# Altium schematic sheet 48V-5V Buck.SchDoc
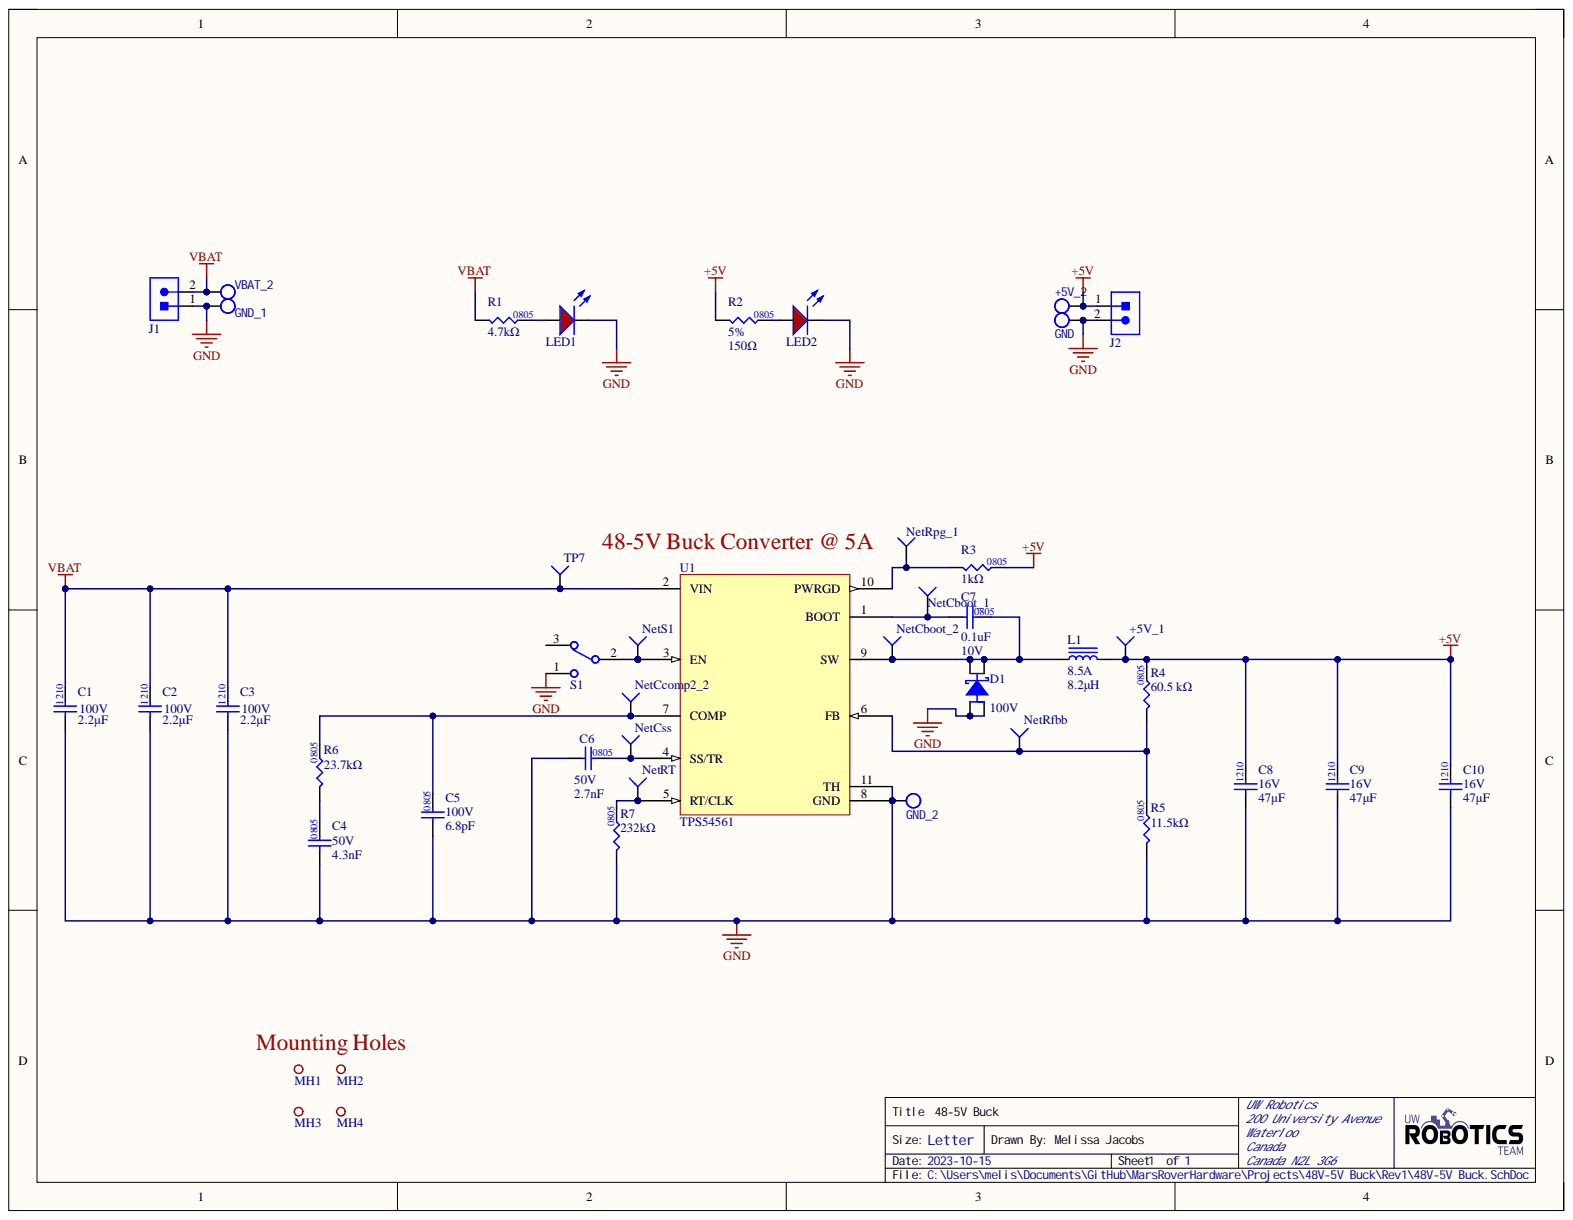
[diagram p0: 48V-5V Buck.SchDoc]

## Parts
+5V_1  TESTPOINT_1MM_CIRCLE  [TESTPOINT_CIRCLE_1MM]  (value not drawn)  (no BOM)
+5V_2  TESTPOINT_LOOP  [TESTPOINT_LOOP]  (value not drawn)
C1  value 2.2μF  Package/Footprint: 1210  Voltage Rating: 100V  [CAPC3225X270N]  (hidden comment: CAP_2.2uF_100V_1210_1)
C2  value 2.2μF  Package/Footprint: 1210  Voltage Rating: 100V  [CAPC3225X270N]  (hidden comment: CAP_2.2uF_100V_1210_1)
C3  value 2.2μF  Package/Footprint: 1210  Voltage Rating: 100V  [CAPC3225X270N]  (hidden comment: CAP_2.2uF_100V_1210_1)
C4  value 4.3nF  Package: 0805  Voltage Rating: 50V  [CAP2012]  (hidden comment: CAP_4.3nF_50V_0805)
C5  value 6.8pF  Package: 0805  Voltage Rating: 100V  [CAP2012]  (hidden comment: CAP_6.8pF_100V_0805_0.1pF)
C6  value 2.7nF  Package/Footprint: 0805  Voltage Rating: 50V  [CAP0805]  (hidden comment: CAP_2.7nF_50V_0805)
C7  value 0.1uF  Package/Footprint: 0805  [CAP0805]  (hidden comment: CAP_0.1uF_10V_0805)
C8  value 47μF  Package/Footprint: 1210  Voltage Rating: 16V  [CAPC3225X270N]  (hidden comment: CAP_47uF_16V_1210)
C9  value 47μF  Package/Footprint: 1210  Voltage Rating: 16V  [CAPC3225X270N]  (hidden comment: CAP_47uF_16V_1210)
C10  value 47μF  Package/Footprint: 1210  Voltage Rating: 16V  [CAPC3225X270N]  (hidden comment: CAP_47uF_16V_1210)
D1  Vr (Max): 100V  [D-PAK]  (hidden comment: DIO_SCHOTTKY_100V_5.5A)
GND  TESTPOINT_LOOP  [TESTPOINT_LOOP]  (value not drawn)
GND_1  TESTPOINT_LOOP  [TESTPOINT_LOOP]  (value not drawn)
GND_2  TESTPOINT_LOOP  [TESTPOINT_LOOP]  (value not drawn)
J1  CON_2POS_SCREW_TERM  [1935161]  (value not drawn)
J2  CON_2POS_SCREW_TERM  [1935161]  (value not drawn)
L1  value 8.2μH  Current Rating: 8.5A  [IND_8.2uH_8.5A]  (hidden comment: IND_8.2uH_8.5A)
LED1  LED_RED  [LED_0805_RED]  (value not drawn)
LED2  Package/Footprint: 0805  [LED_0805_RED]  (hidden comment: LED_RED)
MH1  MOUNTING_HOLE_M3_GIMBAL_REV2  [MOUNTING_HOLE_3mm_GIMBAL_REV2]  (value not drawn)  (no BOM)
MH2  MOUNTING_HOLE_M3_GIMBAL_REV2  [MOUNTING_HOLE_3mm_GIMBAL_REV2]  (value not drawn)  (no BOM)
MH3  MOUNTING_HOLE_M3_GIMBAL_REV2  [MOUNTING_HOLE_3mm_GIMBAL_REV2]  (value not drawn)  (no BOM)
MH4  MOUNTING_HOLE_M3_GIMBAL_REV2  [MOUNTING_HOLE_3mm_GIMBAL_REV2]  (value not drawn)  (no BOM)
NetCboot_1  TESTPOINT_1MM_CIRCLE  [TESTPOINT_CIRCLE_1MM]  (value not drawn)  (no BOM)
NetCboot_2  TESTPOINT_1MM_CIRCLE  [TESTPOINT_CIRCLE_1MM]  (value not drawn)  (no BOM)
NetCcomp2_2  TESTPOINT_1MM_CIRCLE  [TESTPOINT_CIRCLE_1MM]  (value not drawn)  (no BOM)
NetCss  TESTPOINT_1MM_CIRCLE  [TESTPOINT_CIRCLE_1MM]  (value not drawn)  (no BOM)
NetRfbb  TESTPOINT_1MM_CIRCLE  [TESTPOINT_CIRCLE_1MM]  (value not drawn)  (no BOM)
NetRpg_1  TESTPOINT_1MM_CIRCLE  [TESTPOINT_CIRCLE_1MM]  (value not drawn)  (no BOM)
NetRT  TESTPOINT_1MM_CIRCLE  [TESTPOINT_CIRCLE_1MM]  (value not drawn)  (no BOM)
NetS1  TESTPOINT_1MM_CIRCLE  [TESTPOINT_CIRCLE_1MM]  (value not drawn)  (no BOM)
R1  value 4.7kΩ  Package: 0805  [RES0805]  (hidden comment: RES_4.7kΩ_0805_5%)
R2  value 150Ω  Tolerance: 5%  [RES0805]  (hidden comment: RES_150Ω_0805_5%)
R3  value 1kΩ  Package: 0805  [RES0805]  (hidden comment: RES_1kΩ_0805_1%)
R4  value 60.5 kΩ  Package/Footprint: 0805  [RES0805]  (hidden comment: RES_60.4kΩ_0805_1%)
R5  value 11.5kΩ  Package/Footprint: 0805  [RES0805]  (hidden comment: RES_11.5kΩ_0805_0.1%)
R6  value 23.7kΩ  Package: 0805  [RES0805]  (hidden comment: RES_23.7kΩ_0805_1%)
R7  value 232kΩ  Package: 0805  [RES0805]  (hidden comment: RES_232kΩ_0805_1%)
S1  SW_SLIDE_TOGGLE  [SW_SLIDE_TOGGLE]  (value not drawn)
TP7  TESTPOINT_1MM_CIRCLE  [TESTPOINT_CIRCLE_1MM]  (value not drawn)  (no BOM)
U1  TPS54561  [BUCK_TPS54561DPRR]
VBAT_2  TESTPOINT_LOOP  [TESTPOINT_LOOP]  (value not drawn)

## Nets
+5V: +5V_1.1 +5V_2.1 C8.2 C9.2 C10.2 J2.1 L1.2 R2.1 R3.2 R4.2
GND: C1.1 C2.1 C3.1 C4.1 C5.1 C6.1 C8.1 C9.1 C10.1 D1.1 D1.3 GND.1 GND_1.1 GND_2.1 J1.1 J2.2 LED1.2 LED2.2 R5.1 R7.1 S1.1 U1.8(GND) U1.11(TH)
VBAT: C1.2 C2.2 C3.2 J1.2 R1.1 TP7.1 U1.2(VIN) VBAT_2.1
NetC4_2: C4.2 R6.1
NetC5_2: C5.2 NetCcomp2_2.1 R6.2 U1.7(COMP)
NetC6_2: C6.2 NetCss.1 U1.4(SS/TR)
NetC7_1: C7.1 NetCboot_1.1 U1.1(BOOT)
NetC7_2: C7.2 D1.2 D1.4 L1.1 NetCboot_2.1 U1.9(SW)
NetLED1_1: LED1.1 R1.2
NetLED2_1: LED2.1 R2.2
NetNetRfbb_1: NetRfbb.1 R4.1 R5.2 U1.6(FB)
NetNetRpg_1_1: NetRpg_1.1 R3.1 U1.10(PWRGD)
NetNetRT_1: NetRT.1 R7.2 U1.5(RT/CLK)
NetNetS1_1: NetS1.1 S1.2 U1.3(EN)

Not connected: S1 3

## Library data of the parts
+5V_1 NetCboot_1 NetCboot_2 NetCcomp2_2 NetCss NetRfbb NetRpg_1 NetRT NetS1 TP7 = TESTPOINT_1MM_CIRCLE: TESTPOINT 1MM CIRCLE; Library Name: UWRT_Schematic_Library_2020.SchLib
+5V_2 GND GND_1 GND_2 VBAT_2 = TESTPOINT_LOOP: TESTPOINT THRUHOLE; Manufacturer 1: Keystone Electronics; Manufacturer Part Number 1: 5002; Package/Footprint: TH; Component Kind: Standard; Mounting Type: TH; Supplier 1: Digi-Key; Supplier Part Number 1: 36-5002-ND; Library Name: UWRT_Schematic_Library_2020.SchLib
C1-C3 = CAP_2.2uF_100V_1210_1: CAP CER 2.2UF 100V X7R 1210; Manufacturer 1: TDK Corporation; Manufacturer Part Number 1: C3225X7R2A225K230AB; Component Kind: Standard; Mounting Type: SMD; Supplier 1: Digi-Key; Supplier Part Number 1: 445-4497-1-ND; Library Name: UWRT_Schematic_Library_2020.SchLib
C4 = CAP_4.3nF_50V_0805: CAP CER 4.3nF 50V C0G/NP0 0805; Manufacturer 1: KEMET; Manufacturer Part Number 1: C0805C432J5GAC7800; Component Kind: Standard; Mounting Type: SMD; Supplier 1: Digi-Key; Supplier Part Number 1: 399-15050-6-ND; Library Name: UWRT_Schematic_Library_2020.SchLib
C5 = CAP_6.8pF_100V_0805_0.1pF: CAP CER 6.8pF 100V C0G/NP0 0805; Manufacturer 1: KEMET; Manufacturer Part Number 1: CBR08C689B1GAC; Component Kind: Standard; Mounting Type: SMD; Supplier 1: Digi-Key; Supplier Part Number 1: 399-8705-6-ND; Library Name: UWRT_Schematic_Library_2020.SchLib
C6 = CAP_2.7nF_50V_0805: CAP CER 2700PF 50V C0G 0805; Manufacturer 1: TDK Corporation; Manufacturer Part Number 1: C2012C0G1H272J060AA; Value: 2.7nF; Component Kind: Standard; Supplier 1: Digi-Key; Supplier Part Number 1: 445-7508-1-ND; Library Name: UWRT_Schematic_Library_2020.SchLib
C7 = CAP_0.1uF_10V_0805: CAP CER 0.1uF 10V 0805; Manufacturer 1: KEMET; Manufacturer Part Number 1: C0805C104K8RAC7800; Component Kind: Standard; Supplier 1: Digi-Key; Supplier Part Number 1: 399-C0805C104K8RAC7800DKR-ND; Voltage Rating: 10V; Library Name: UWRT_Schematic_Library_2020.SchLib
C8-C10 = CAP_47uF_16V_1210: CAP CER 47uF 16V X5R 1210; Manufacturer 1: Murata Electronics; Manufacturer Part Number 1: GRM32ER61C476KE15L; Component Kind: Standard; Mounting Type: SMD; Supplier 1: Digi-Key; Supplier Part Number 1: 490-6539-6-ND; Library Name: UWRT_Schematic_Library_2020.SchLib
D1 = DIO_SCHOTTKY_100V_5.5A: DIODE SCHOTTKY 100V 5.5A DPAK; Manufacturer 1: Vishay General Semiconductor - Diodes Division; Manufacturer Part Number 1: VS-50WQ10FN-M3; Package/Footprint: TO-252-3, DPak (2 Leads + Tab), SC-63; Component Kind: Standard; Mounting Type: SMD; Supplier 1: Digi-Key; Supplier Part Number 1: VS-50WQ10FN-M3-ND; Library Name: UWRT_Schematic_Library_2020.SchLib
J1 J2 = CON_2POS_SCREW_TERM: TERM BLK 2POS SIDE ENTRY 5MM; Manufacturer 1: Phoenix Contact; Package/Footprint: SMD; Component Kind: Standard; Manufacturer Part Number 1: 1935161; Mounting Type: TH; Supplier 1: Digi-Key; Supplier Part Number 1: 277-1667-ND; Library Name: UWRT_Schematic_Library_2020.SchLib
L1 = IND_8.2uH_8.5A: FIXED IND 8.2UH 8.5A 28 MOHM SMD; Manufacturer 1: Vishay Dale; Manufacturer Part Number 1: IHLP5050CEER8R2M01; Component Kind: Standard; Mounting Type: SMD; Package/Footprint: SMD; Supplier 1: Digi-Key; Supplier Part Number 1: 541-2596-6-ND; Library Name: UWRT_Schematic_Library_2020.SchLib
LED1 = LED_RED: LED RED DIFFUSED 0805 SMD; Manufacturer 1: OSRAM; Manufacturer Part Number 1: LS R976-NR-1; Forward Voltage Drop: 2V; Package/Footprint: 0805; Component Kind: Standard; Mounting Type: SMD; Supplier 1: Digi-Key; Supplier Part Number 1: 475-1278-1-ND; Library Name: UWRT_Schematic_Library_2020.SchLib
LED2 = LED_RED: LED RED DIFFUSED 0805 SMD; Manufacturer 1: OSRAM; Manufacturer Part Number 1: LS R976-NR-1; Forward Voltage Drop: 2V; Component Kind: Standard; Mounting Type: SMD; Supplier 1: Digi-Key; Supplier Part Number 1: 475-1278-1-ND; Library Name: UWRT_Schematic_Library_2020.SchLib
MH1-MH4 = MOUNTING_HOLE_M3_GIMBAL_REV2: MOUNTING_HOLE_M3 Gimbal Rev2; Library Name: UWRT_Schematic_Library_2020.SchLib
R1 = RES_4.7kΩ_0805_5%: RES 4.7K OHM 5% 1/8W 0805; Manufacturer 1: Yageo; Manufacturer Part Number 1: RC0805JR-074K7L; Component Kind: Standard; LCSC Part #: C17673; Mounting Type: SMD; Supplier 1: Digi-Key; Supplier Part Number 1: 311-4.7KARCT-ND; Library Name: UWRT_Schematic_Library_2020.SchLib
R2 = RES_150Ω_0805_5%: RES 150 OHM 5% 1/8W 0805; Manufacturer 1: YAGEO; Manufacturer Part Number 1: RC0805JR-07150RL; Component Kind: Standard; Mounting Type: SMD; Supplier 1: Digi-Key; Supplier Part Number 1: 311-150ARDKR-ND; Library Name: UWRT_Schematic_Library_2020.SchLib
R3 = RES_1kΩ_0805_1%: RES 1K OHM 1% 1/8W 0805; Manufacturer 1: Yageo; Manufacturer Part Number 1: RC0805FR-071K07L; Component Kind: Standard; Mounting Type: SMD; Supplier 1: Digi-Key; Supplier Part Number 1: 311-1.07KCRCT-ND; Library Name: UWRT_Schematic_Library_2020.SchLib
R4 = RES_60.4kΩ_0805_1%: RES 60.5 kOHM 63 mW 0805; Manufacturer 1: Vishay Dale; Manufacturer Part Number 1: RCS080560K4FKEA; Component Kind: Standard; Mounting Type: SMD; Supplier 1: Digi-Key; Supplier Part Number 1: 541-RCS080560K4FKEADKR-ND; Library Name: UWRT_Schematic_Library_2020.SchLib
R5 = RES_11.5kΩ_0805_0.1%: RES 11.5K OHM 0.1% 1/10W 0805; Manufacturer 1: TE Connectivity Passive Product; Manufacturer Part Number 1: RN73C2A11K5BTDF; Component Kind: Standard; Mounting Type: SMD; Supplier 1: Digi-Key; Supplier Part Number 1: A103292DKR-ND; Library Name: UWRT_Schematic_Library_2020.SchLib
R6 = RES_23.7kΩ_0805_1%: RES 1.07K OHM 1% 1/8W 0805; Manufacturer 1: Yageo; Manufacturer Part Number 1: RC0805FR-0723K7L; Component Kind: Standard; Mounting Type: SMD; Supplier 1: Digi-Key; Supplier Part Number 1: 311-23.7KCRDKR-ND; Library Name: UWRT_Schematic_Library_2020.SchLib
R7 = RES_232kΩ_0805_1%: RES 232K OHM 1% 1/8W 0805; Manufacturer 1: Vishay Dale; Manufacturer Part Number 1: CRCW0805232RFKEA; Component Kind: Standard; LCSC Part #: 0; Mounting Type: SMD; Supplier 1: Digi-Key; Supplier Part Number 1: 541-232CDKR-ND; Library Name: UWRT_Schematic_Library_2020.SchLib
S1 = SW_SLIDE_TOGGLE: SWITCH SLIDE SPDT 200MA 30V; Manufacturer 1: E-Switch; Manufacturer Part Number 1: 100SP1T1B4M2QE; Package/Footprint: TH; Component Kind: Standard; Mounting Type: TH; Supplier 1: Digi-Key; Supplier Part Number 1: EG1903-ND; Throw Configuration: SPDT; Voltage Rating (DC): 30V; Library Name: UWRT_Schematic_Library_2020.SchLib
U1 = TPS54561: Buck Switching Regulator IC Positive Adjustable 0.8V 1 Output 5A 10-WDFN Exposed Pad; Manufacturer 1: Texas Instruments; Manufacturer Part Number 1: TPS54561DPRR; Component Kind: Standard; Mounting Type: SMD; Package/Footprint: 10-WDFN Exposed Pad; Supplier 1: Digi-Key; Supplier Part Number 1: 296-40803-1-ND; Library Name: UWRT_Schematic_Library_2020.SchLib
